# Altium schematic sheet Sheet5.SchDoc(Sheet5)
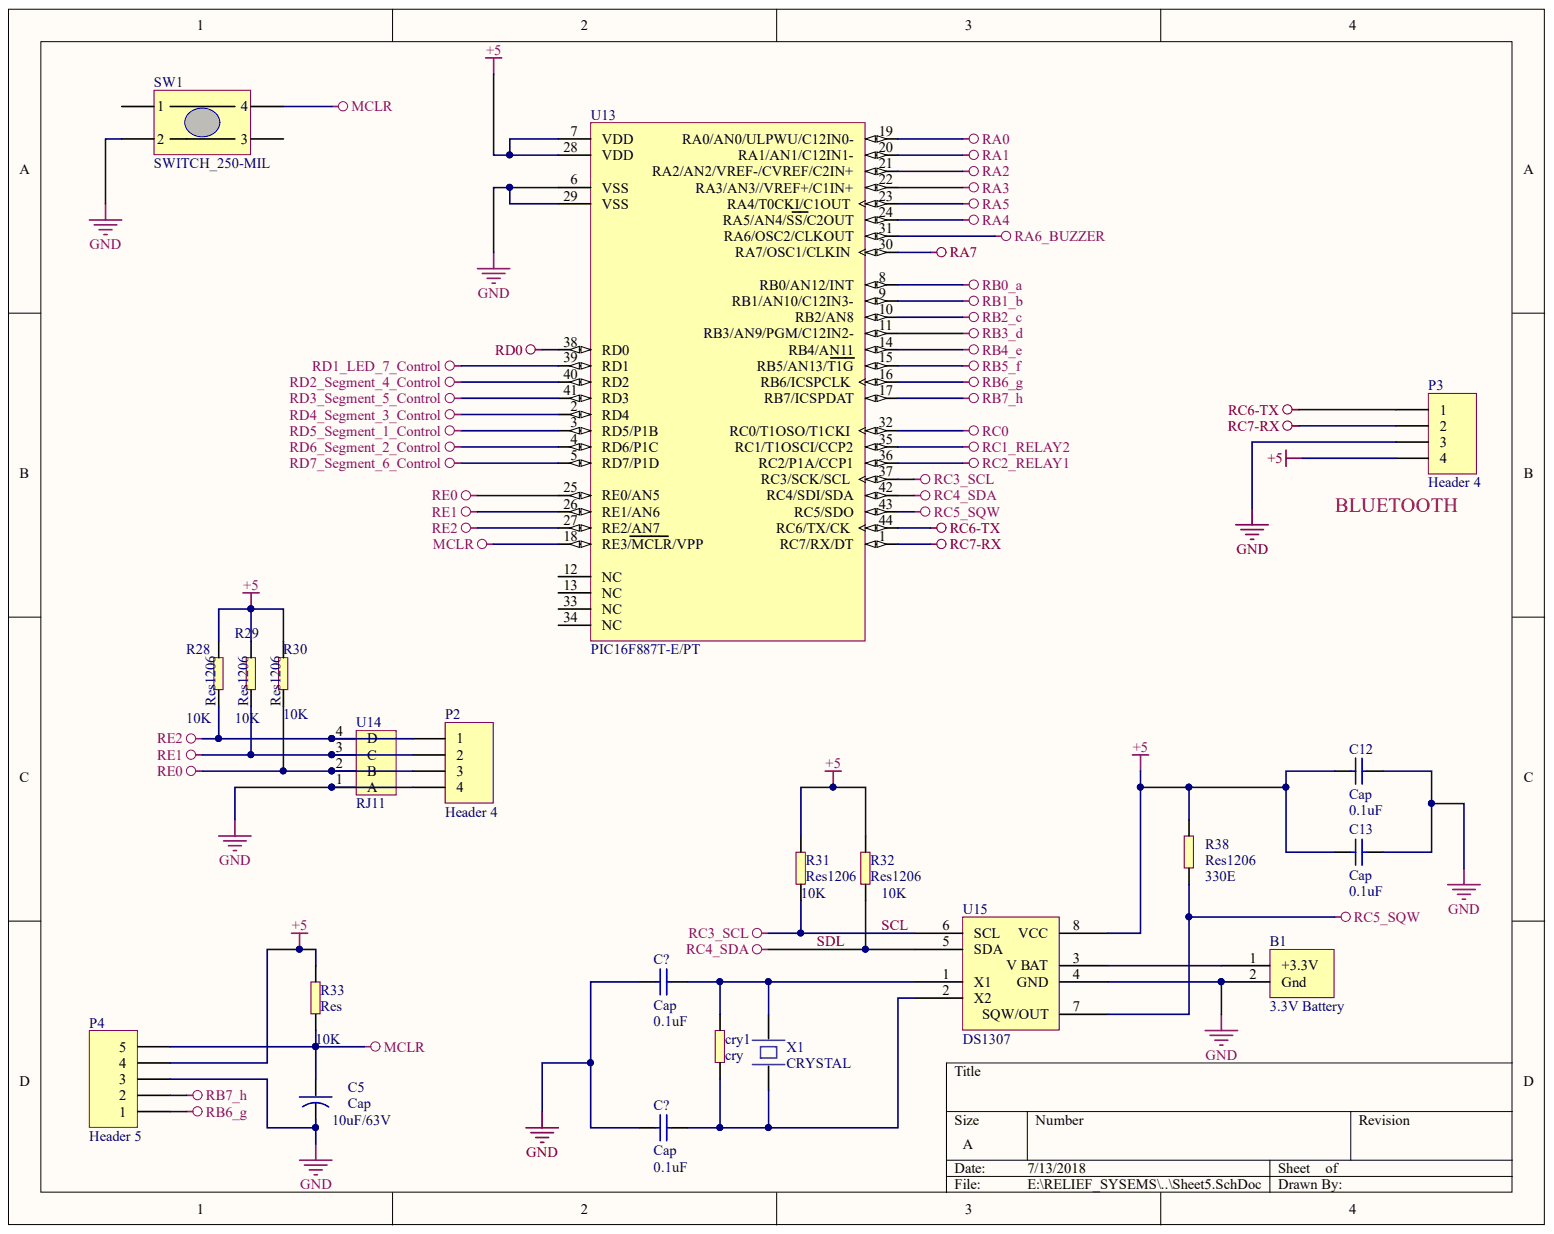
[diagram p0: Sheet5.SchDoc]

## Parts
B1  3.3V Battery  [CMOS_Battery_H]
C5  Cap  value 10uF/63V  [Capacitor10UF/63V]
C12  Cap  [RES0805]
C13  Cap  [RES0805]
C?  Cap  [RES0805]
C?  Cap  [RES0805]
cry1  cry  [Res_150mil]
P2  Header 4  [HDR1X4]
P3  Header 4  [HDR1X4]
P4  Header 5  [HDR1X5]
R28  Res1206  value 10K  [1206]
R29  Res1206  value 10K  [1206]
R30  Res1206  value 10K  [1206]
R31  Res1206  value 10K  [1206]
R32  Res1206  value 10K  [1206]
R33  Res  value 10K  [RES0805]
R38  Res1206  value 330E  [1206]
SW1  SWITCH_250-MIL  [switch_200/250]
U13  PIC16F887T-E/PT  [TQFP-PT44_N]
U14  RJ11  [RJ11]
U15  DS1307  [SOIC1307]
X1  CRYSTAL  [CRYSTAL]

## Nets
+5: C12.2 C13.2 P3.4 P4.4 R28.2 R29.2 R30.2 R31.2 R32.2 R33.2 R38.2 U13.7(VDD) U13.28(VDD) U15.8(VCC)
GND: B1.2 C5.2 C12.1 C13.1 C?.2 P2.4 P3.3 P4.3 SW1.2 U13.6(VSS) U13.29(VSS) U14.1(A) U15.4(GND)
MCLR: C5.1 P4.5 R33.1 SW1.4 U13.18(RE3/MCLR/VPP)
RA0: U13.19(RA0/AN0/ULPWU/C12IN0-)
RA1: U13.20(RA1/AN1/C12IN1-)
RA2: U13.21(RA2/AN2/VREF-/CVREF/C2IN+)
RA3: U13.22(RA3/AN3//VREF+/C1IN+)
RA4: U13.24(RA5/AN4/SS/C2OUT)
RA5: U13.23(RA4/T0CKI/C1OUT)
RA6_BUZZER: U13.31(RA6/OSC2/CLKOUT)
RA7: U13.30(RA7/OSC1/CLKIN)
RB0_A: U13.8(RB0/AN12/INT)
RB1_B: U13.9(RB1/AN10/C12IN3-)
RB2_C: U13.10(RB2/AN8)
RB3_D: U13.11(RB3/AN9/PGM/C12IN2-)
RB4_E: U13.14(RB4/AN11)
RB5_F: U13.15(RB5/AN13/T1G)
RB6_G: P4.1 U13.16(RB6/ICSPCLK)
RB7_H: P4.2 U13.17(RB7/ICSPDAT)
RC0: U13.32(RC0/T1OSO/T1CKI)
RC1_RELAY2: U13.35(RC1/T1OSCI/CCP2)
RC2_RELAY1: U13.36(RC2/P1A/CCP1)
RC5_SQW: R38.1 U13.43(RC5/SDO) U15.7(SQW/OUT)
RC6-TX: P3.1 U13.44(RC6/TX/CK)
RC7-RX: P3.2 U13.1(RC7/RX/DT)
RD0: U13.38(RD0)
RD1_LED_7_CONTROL: U13.39(RD1)
RD2_SEGMENT_4_CONTROL: U13.40(RD2)
RD3_SEGMENT_5_CONTROL: U13.41(RD3)
RD4_SEGMENT_3_CONTROL: U13.2(RD4)
RD5_SEGMENT_1_CONTROL: U13.3(RD5/P1B)
RD6_SEGMENT_2_CONTROL: U13.4(RD6/P1C)
RD7_SEGMENT_6_CONTROL: U13.5(RD7/P1D)
RE0: P2.3 R30.1 U13.25(RE0/AN5) U14.2(B)
RE1: P2.2 R29.1 U13.26(RE1/AN6) U14.3(C)
RE2: P2.1 R28.1 U13.27(RE2/AN7) U14.4(D)
SCL: R31.1 U13.37(RC3/SCK/SCL) U15.6(SCL)
SDL: R32.1 U13.42(RC4/SDI/SDA) U15.5(SDA)
NetB1_1: B1.1 U15.3(V_BAT)
NetC?_1: C?.1 cry1.1 cry1.2 U15.1(X1) U15.2(X2) X1.1 X1.2

Not connected: SW1 1 3; U13 12(NC) 13(NC) 33(NC) 34(NC)

## Library data of the parts
B1 = CMOS Battery_H: 3.3V; Component Kind: Standard; Library Name: Dinesh_New_Integrated_Library.IntLib
C5 = Cap-10uf/63V: Cap10uf/63V; Component Kind: Standard; Library Name: VIJAYIntegrated_Library.IntLib
C12 C13 C? C? = CAP_104_SMD: : 0.1uF; Component Kind: Standard; Description: Cap_104_SMD; Library Name: Dinesh_New_Integrated_Library.IntLib
cry1 = Res vertical placement: Component Kind: Standard; Description: Res vertical placement; Library Name: Dinesh_New_Integrated_Library.IntLib
P2 P3 = Header 4: Header, 4-Pin; Component Kind: Standard; LatestRevisionDate: 17-Jul-2002; LatestRevisionNote: Re-released for DXP Platform.; Library Name: Miscellaneous Connectors.IntLib; Signal Integrity: Connector; Publisher: Altium Limited
P4 = Header 5: Header, 5-Pin; Component Kind: Standard; LatestRevisionDate: 17-Jul-2002; LatestRevisionNote: Re-released for DXP Platform.; Library Name: Miscellaneous Connectors.IntLib; Signal Integrity: Connector; Publisher: Altium Limited
R33 = Resistor: Res0805; Component Kind: Standard; Library Name: Dinesh_New_Integrated_Library.IntLib
SW1 = SWITCH_250-MIL: 200/250mil Didtance; Component Kind: Standard; Library Name: VIJAYIntegrated_Library.IntLib
U13 = PIC16F887T-E/PT: Flash-Based, 8-Bit CMOS Microcontroller with nanoWatt Technology, 8K (x14-Bit words) Program Memory, 624 Bytes Data Memory, 35 I/O pins, 44-Pin TQFP, Standard VDD Range, Extended Temperature, Tape and Reel; Code_JEDEC: MS-026; Component Kind: Standard; ComponentLink1Description: Datasheet; ComponentLink1URL: http://ww1.microchip.com/downloads/en/DeviceDoc/41291F.pdf; ComponentLink2Description: Packaging Specification; ComponentLink2URL: http://www.microchip.com/stellent/groups/techpub_sg/documents/packagingspec/en012702.pdf; ComponentLink3Description: Microchip.com Home; ComponentLink3URL: http://www.microchip.com/; DatasheetVersion: revF, Apr-2009; LatestRevisionDate: 02-Dec-2009; LatestRevisionNote: Updated datasheet to version revF, Apr-2009.; Library Name: Microchip Microcontroller 8-Bit PIC16.IntLib; PackageDescription: 44-Lead Plastic Thin Quad Flatpack (PT) - 10x10x1mm Body, 2.00mm [TQFP]; PackageReference: TQFP-PT44; PackageVersion: revBB, Aug-2009; Published: 29-Jan-2009; Publisher: Altium Limited
U14 = RJ11 conn: Component Kind: Standard; Description: RJ11 conn; Library Name: Dinesh_New_Integrated_Library.IntLib
R28-R32 R38 = Res1206: Component Kind: Standard; Description: Res1206; Library Name: Dinesh_New_Integrated_Library.IntLib
U15 = DS1307: Component Kind: Standard; Library Name: VIJAYIntegrated_Library.IntLib
X1 = CRYSTAL: Component Kind: Standard; Library Name: Dinesh_New_Integrated_Library.IntLib
